# Altium schematic sheet Sheet1.SchDoc
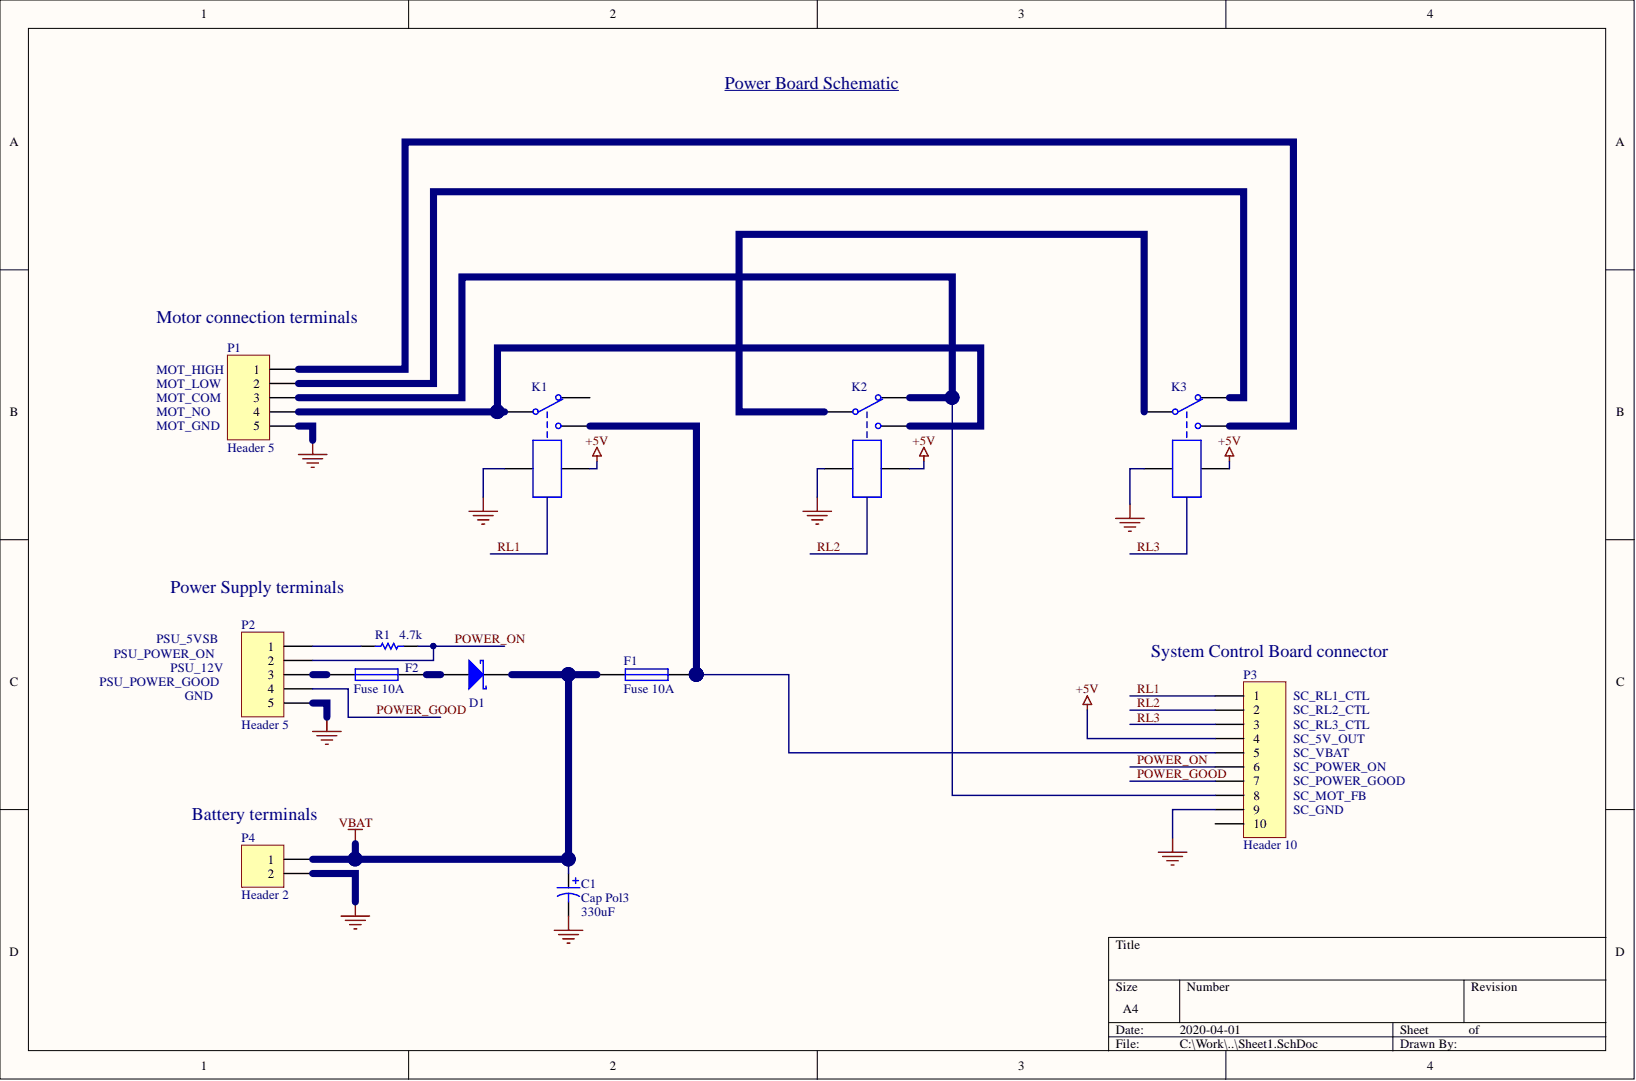
[diagram p0: Sheet1.SchDoc]

## Parts
C1  Cap Pol3  value 330uF  [1812]
D1  Diode BAS70  [SOT-23_L]  (value not drawn)
F1  Fuse 10A  [PIN-W2/E2.8]
F2  Fuse 10A  [PIN-W2/E2.8]
K1  Relay-SPDT  [MODULE5B]  (value not drawn)
K2  Relay-SPDT  [MODULE5B]  (value not drawn)
K3  Relay-SPDT  [MODULE5B]  (value not drawn)
P1  Header 5  [HDR1X5]
P2  Header 5  [HDR1X5]
P3  Header 10  [HDR1X10]
P4  Header 2  [HDR1X2]
R1  4.7k  [AXIAL-0.3]

## Nets
+5V: K1.5 K2.5 K3.5 P3.4
GND: C1.2 K1.4 K2.4 K3.4 P1.5 P2.5 P3.9 P4.2
VBAT: C1.1 D1.3 F1.2 P4.1
POWER_GOOD: P2.4 P3.7
POWER_ON: P2.2 P3.6 R1.2
RL1: P3.1
RL2: P3.2
RL3: P3.3
NetD1_1: D1.1 F2.2
NetF1_1: F1.1 K1.3 P3.5
NetF2_1: F2.1 P2.3
NetK1_1: K1.1 K2.3 P1.4
NetK2_1: K2.1 K3.1
NetK2_2: K2.2 P1.3 P3.8
NetK3_2: K3.2 P1.2
NetK3_3: K3.3 P1.1
NetP2_1: P2.1 R1.1

Not connected: K1 2; P3 10

## Library data of the parts
C1 = Cap Pol3: Polarized Capacitor (Surface Mount); Component Kind: Standard; LatestRevisionDate: 29-May-2009; LatestRevisionNote: IPC-7351 Footprint Added.; Library Name: Miscellaneous Devices.IntLib; PackageDescription: Chip Capacitor; PackageReference: C0805; Published: 8-Jun-2000; Simulation: CAP; Publisher: Altium Limited
D1 = Diode BAS70: Silicon AF Schottky Diode for High-Speed Switching; Note: Pin 2 is not connected and not drawn.; Component Kind: Standard; ComponentLink1Description: Manufacturer Link; ComponentLink1URL: http://www.infineon.com/; ComponentLink2Description: Datasheet; ComponentLink2URL: http://www.infineon.com/upload/Document/cmc_upload/documents/009/382/bas40_bas140series_1.pdf; DatasheetVersion: Oct-1999; LatestRevisionDate: 29-May-2009; LatestRevisionNote: IPC-7351 Footprint Added.; Library Name: Miscellaneous Devices.IntLib; PackageDescription: 3-Pin SOT-23 Package 0.95 mm Pitch, 2.5 mm Lead Span; PackageReference: SOT-23; PackageVersion: Sep-2001; Published: 21-Mar-2003; Simulation: BAS70; Publisher: Altium Limited
F1 F2 = Fuse 1: Fuse; Component Kind: Standard; LatestRevisionDate: 17-Jul-2002; LatestRevisionNote: Re-released for DXP Platform.; Library Name: Miscellaneous Devices.IntLib; PackageDescription: Thru-Hole; 2 Leads; PackageReference: PIN-W2/E2.8; Published: 8-Jun-2000; Simulation: FUSE; Publisher: Altium Limited
K1-K3 = Relay-SPDT: Single-Pole Dual-Throw Relay; Component Kind: Standard; LatestRevisionDate: 17-Jul-2002; LatestRevisionNote: Re-released for DXP Platform.; Library Name: Miscellaneous Devices.IntLib; PackageReference: MODULE5B; Published: 24-Mar-1999; Simulation: SPDTRELAY; Publisher: Altium Limited
P1 P2 = Header 5: Header, 5-Pin; Component Kind: Standard; LatestRevisionDate: 17-Jul-2002; LatestRevisionNote: Re-released for DXP Platform.; Library Name: Miscellaneous Connectors.IntLib; Publisher: Altium Limited
P3 = Header 10: Header, 10-Pin; Component Kind: Standard; LatestRevisionDate: 17-Jul-2002; LatestRevisionNote: Re-released for DXP Platform.; Library Name: Miscellaneous Connectors.IntLib; Publisher: Altium Limited
P4 = Header 2: Header, 2-Pin; Component Kind: Standard; LatestRevisionDate: 17-Jul-2002; LatestRevisionNote: Re-released for DXP Platform.; Library Name: Miscellaneous Connectors.IntLib; Publisher: Altium Limited
R1 = Res1: Resistor; Component Kind: Standard; LatestRevisionDate: 17-Jul-2002; LatestRevisionNote: Re-released for DXP Platform.; Library Name: Miscellaneous Devices.IntLib; PackageDescription: Axial Device, Thru-Hole; 2 Leads; 0.3 in Pin Spacing; PackageReference: AXIAL-0.3; Published: 8-Jun-2000; Simulation: RESISTOR; Value: 4.7k; Publisher: Altium Limited
